# Altium schematic sheet 1.1.5_Interface_board_Servos.SchDoc
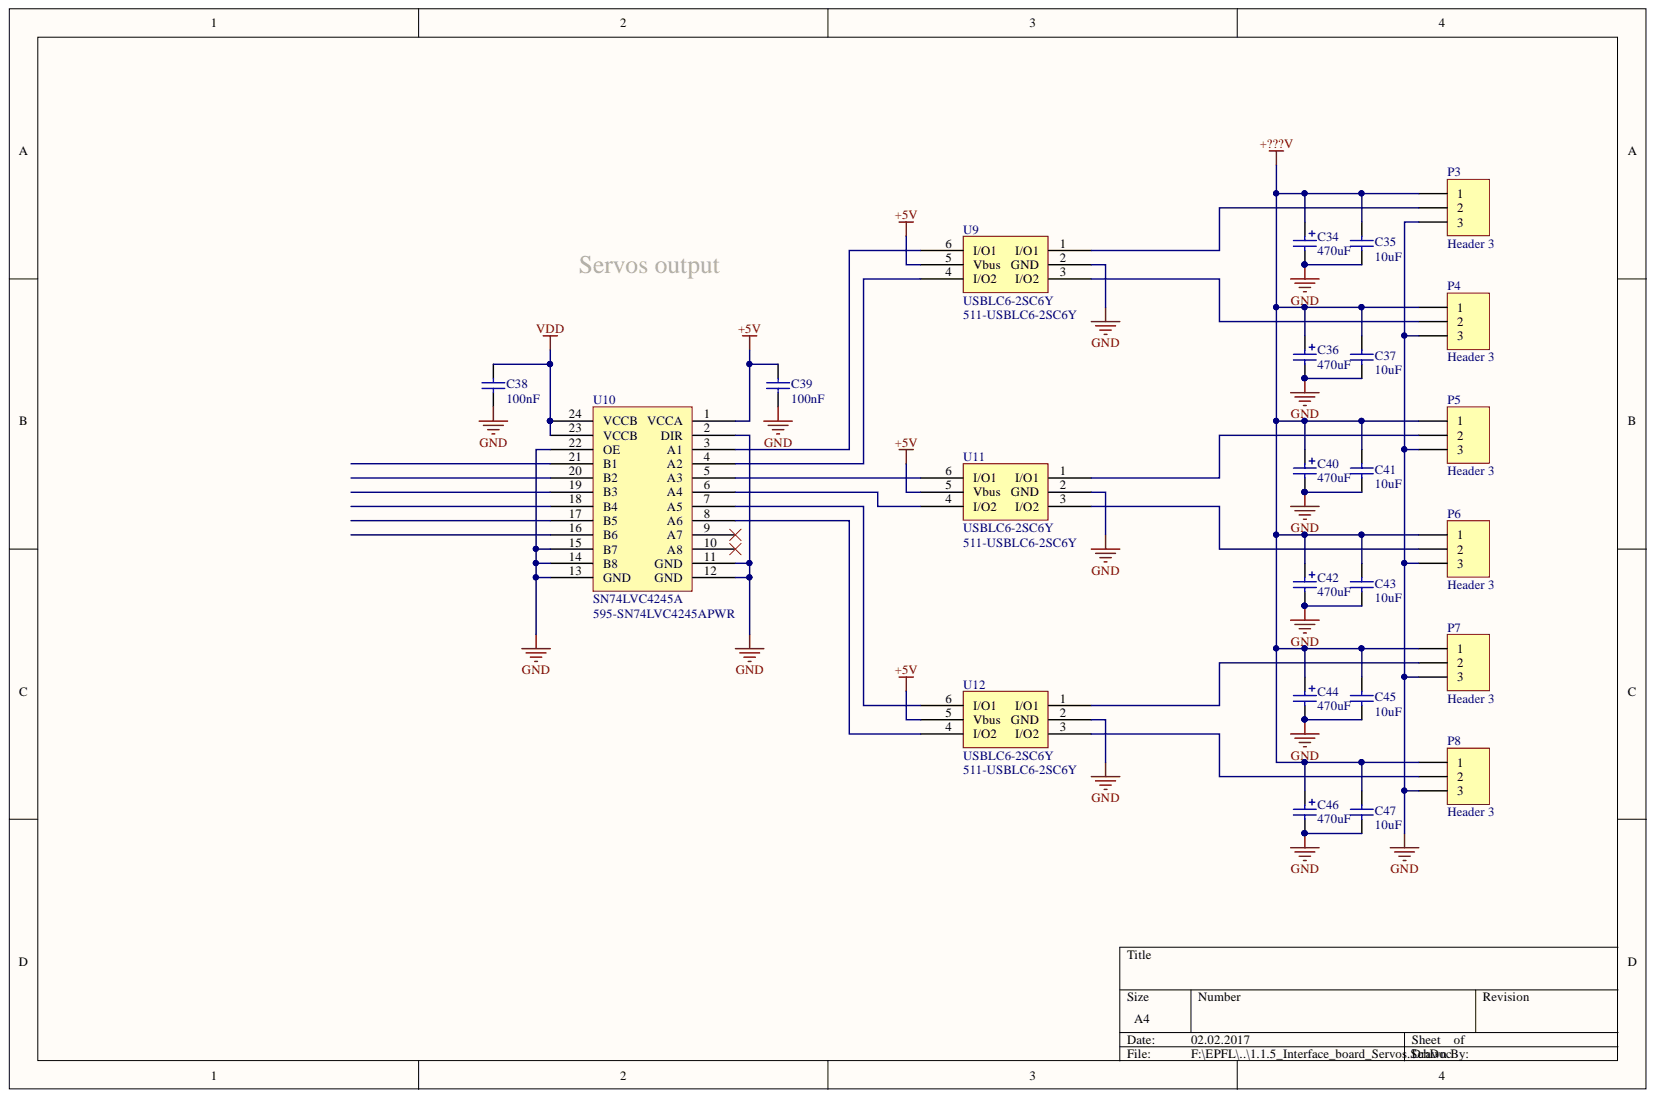
[diagram p0: 1.1.5_Interface_board_Servos.SchDoc]

## Parts
C34  470uF  [PCV_10x10]
C35  10uF  [CAP0805W]
C36  470uF  [PCV_10x10]
C37  10uF  [CAP0805W]
C38  100nF  [CAP0603R]
C39  100nF  [CAP0603R]
C40  470uF  [PCV_10x10]
C41  10uF  [CAP0805W]
C42  470uF  [PCV_10x10]
C43  10uF  [CAP0805W]
C44  470uF  [PCV_10x10]
C45  10uF  [CAP0805W]
C46  470uF  [PCV_10x10]
C47  10uF  [CAP0805W]
P3  Header 3  [HDR1X3]
P4  Header 3  [HDR1X3]
P5  Header 3  [HDR1X3]
P6  Header 3  [HDR1X3]
P7  Header 3  [HDR1X3]
P8  Header 3  [HDR1X3]
U9  USBLC6-2SC6Y  Supplier Part Number 1: 511-USBLC6-2SC6Y  [SOT23-6L]
U10  SN74LVC4245A  Supplier Part Number 1: 595-SN74LVC4245APWR  [TSSOP 24]
U11  USBLC6-2SC6Y  Supplier Part Number 1: 511-USBLC6-2SC6Y  [SOT23-6L]
U12  USBLC6-2SC6Y  Supplier Part Number 1: 511-USBLC6-2SC6Y  [SOT23-6L]

## Nets
+5V: C39.2 U9.5(Vbus) U10.1(VCCA) U11.5(Vbus) U12.5(Vbus)
+???V: C34.1 C35.2 C36.1 C37.2 C40.1 C41.2 C42.1 C43.2 C44.1 C45.2 C46.1 C47.2 P3.1 P4.1 P5.1 P6.1 P7.1 P8.1
GND: C34.2 C35.1 C36.2 C37.1 C38.1 C39.1 C40.2 C41.1 C42.2 C43.1 C44.2 C45.1 C46.2 C47.1 P3.3 P4.3 P5.3 P6.3 P7.3 P8.3 U9.2(GND) U10.2(DIR) U10.11(GND) U10.12(GND) U10.13(GND) U10.14(B8) U10.15(B7) U10.22(OE) U11.2(GND) U12.2(GND)
VDD: C38.2 U10.23(VCCB) U10.24(VCCB)
NetP3_2: P3.2 U9.1(I/O1)
NetP4_2: P4.2 U9.3(I/O2)
NetP5_2: P5.2 U11.1(I/O1)
NetP6_2: P6.2 U11.3(I/O2)
NetP7_2: P7.2 U12.1(I/O1)
NetP8_2: P8.2 U12.3(I/O2)
NetU9_4: U9.4(I/O2) U10.4(A2)
NetU9_6: U9.6(I/O1) U10.3(A1)
NetU10_5: U10.5(A3) U11.6(I/O1)
NetU10_6: U10.6(A4) U11.4(I/O2)
NetU10_7: U10.7(A5) U12.6(I/O1)
NetU10_8: U10.8(A6) U12.4(I/O2)

Not connected: U10 9(A7) 10(A8) 16(B6) 17(B5) 18(B4) 19(B3) 20(B2) 21(B1)

## Library data of the parts
C34 C36 C40 C42 C44 C46 = C_pol_470uF_elec: Polarized Capacitor; Component Kind: Standard; Supplier 1: Mouser; Supplier Part Number 1: 647-UWT1E471MNL1S; Library Name: Rocket_library.SchLib
C35 C37 C41 C43 C45 C47 = C_10uF_16v: Capacitor; Component Kind: Standard; Supplier 1: Mouser; Supplier Part Number 1: 81-GRT21BC81C106ME1L; Library Name: Rocket_library.SchLib
C38 C39 = C_100nF: Capacitor; Component Kind: Standard; Signal Integrity: Cap; Simulation: CAP; Supplier 1: Mouser; Supplier Part Number 1: 81-GCG188R91H104KA1D; Library Name: Rocket_library.SchLib
P3-P8 = Header 3: Header, 3-Pin; Component Kind: Standard; LatestRevisionDate: 17-Jul-2002; LatestRevisionNote: Re-released for DXP Platform.; Library Name: Miscellaneous Connectors.IntLib; Signal Integrity: Connector; Publisher: Altium Limited
U9 U11 U12 = USBLC6-2SC6Y: ESD Suppressors; Component Kind: Standard; Supplier 1: Mouser; Library Name: Rocket_library.SchLib
U10 = SN74LVC4245A: Level shifter 8; Component Kind: Standard; Supplier 1: Mouser; Library Name: Rocket_library.SchLib
